# Altium schematic sheet PowerBoard Secondary.SchDoc
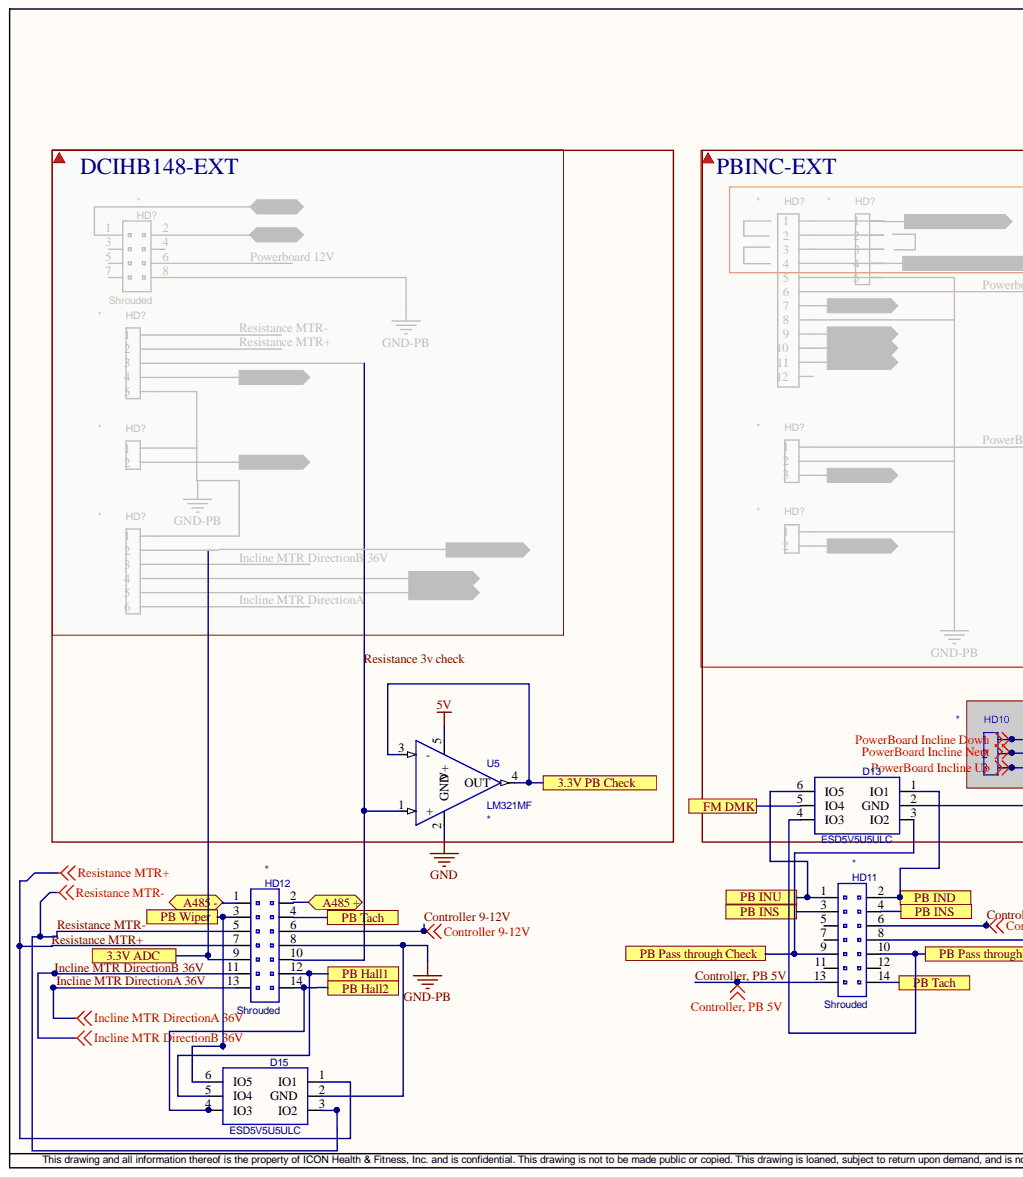
[diagram p0: PowerBoard Secondary.SchDoc, left]
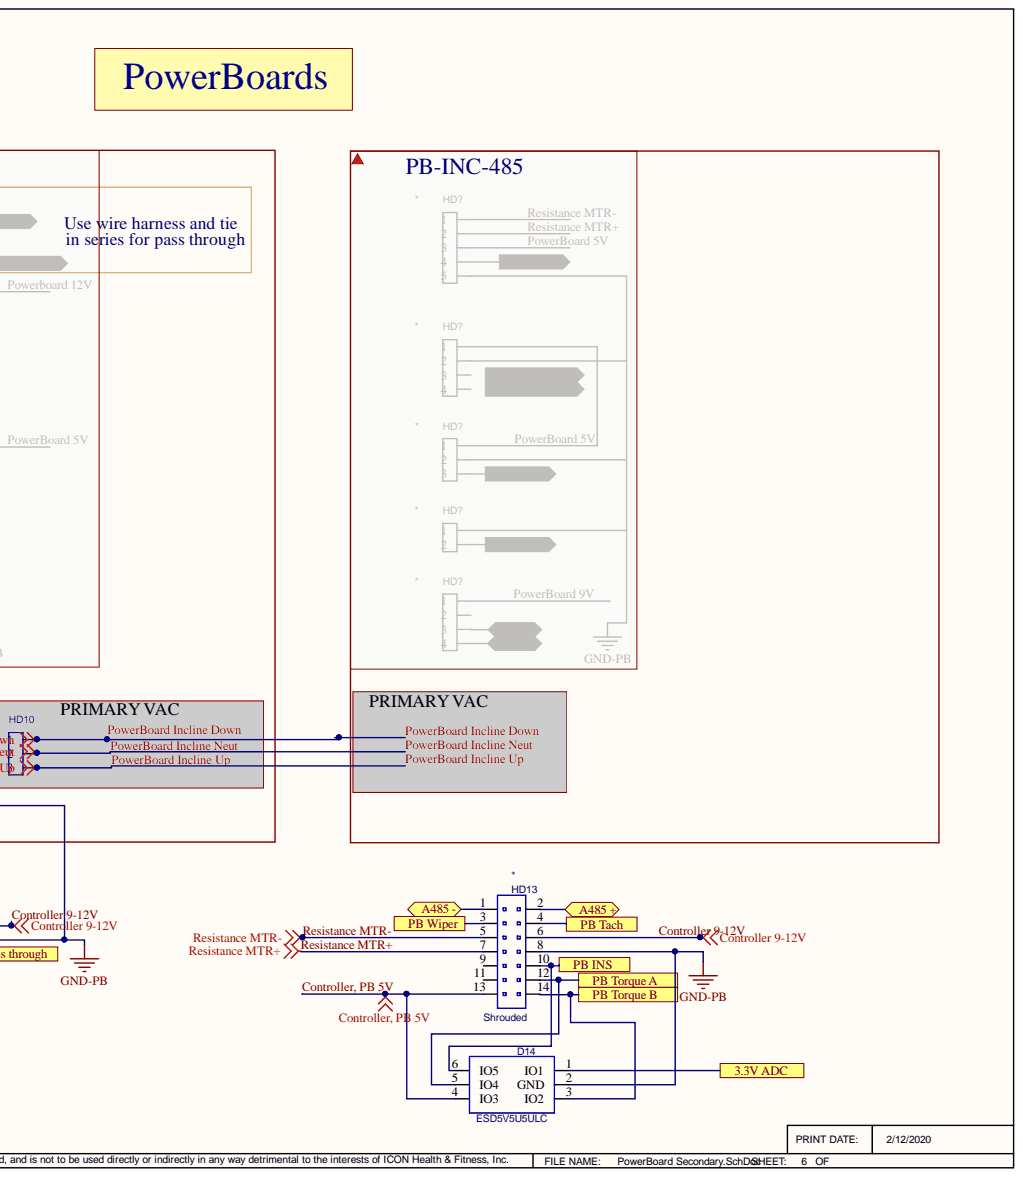
[diagram p1: PowerBoard Secondary.SchDoc, right]

## Parts
D13  ESD5V5U5ULC  [SOT457-6]
D14  ESD5V5U5ULC  [SOT457-6]
D15  ESD5V5U5ULC  [SOT457-6]
HD10  HDR3PIN  [HDR3PIN_JST_XA_2.5MM]  (value not drawn)
HD11  HeaderType: Shrouded  [HDR_2.5MM_14PIN_JST_XAD]  (hidden comment: HDR_14PIN_DUALROW_JST)
HD12  HeaderType: Shrouded  [HDR_2.5MM_14PIN_JST_XAD]  (hidden comment: HDR_14PIN_DUALROW_JST)
HD13  HeaderType: Shrouded  [HDR_2.5MM_14PIN_JST_XAD]  (hidden comment: HDR_14PIN_DUALROW_JST)
U5  value LM321MF  [SOT23-5_REFLOW]  (hidden comment: LM321_SOT23-5)

## Ports
3.3V ADC, 3.3V PB Check, A485 +, A485 -, FM DMK, PB Hall1, PB Hall2, PB IND, PB INS, PB INU, PB Pass through, PB Pass through Check, PB Tach, PB Torque A, PB Torque B, PB Wiper

## Nets
5V: U5.5(V+)
GND: U5.2(GND)
GND-PB: D13.2(GND) D14.2(GND) D15.2(GND) HD11.8 HD12.8 HD13.8
3.3V ADC: D14.1(IO1) HD12.9 ; port 3.3V ADC
3.3V PB Check: U5.3(-) U5.4(OUT) ; port 3.3V PB Check
Controller 9-12V: HD11.6 HD12.6 HD13.6
Controller, PB 5V: D14.4(IO3) HD11.13 HD13.13
FM DMK: D13.5(IO4) ; port FM DMK
Incline MTR DirectionA 36V: HD12.13
Incline MTR DirectionB 36V: HD12.11
PB Hall1: D15.5(IO4) HD12.12 ; port PB Hall1
PB Hall2: D15.4(IO3) HD12.14 ; port PB Hall2
PB IND: D13.1(IO1) HD11.2 ; port PB IND
PB INS: D14.6(IO5) HD11.3 HD11.4 HD13.10 ; port PB INS
PB INU: D13.6(IO5) HD11.1 ; port PB INU
PB Pass through: D13.4(IO3) HD11.10 ; port PB Pass through
PB Pass through Check: D13.3(IO2) HD11.9 ; port PB Pass through Check
PB Tach: HD11.14 HD12.4 HD13.4 ; port PB Tach
PB Torque A: D14.5(IO4) HD13.12 ; port PB Torque A
PB Torque B: D14.3(IO2) HD13.14 ; port PB Torque B
PB Wiper: D15.6(IO5) HD12.3 HD13.3 ; port PB Wiper
PowerBoard Incline Down: HD10.1
PowerBoard Incline Neut: HD10.2
PowerBoard Incline Up: HD10.3
Resistance 3v check: HD12.10 U5.1(+)
Resistance MTR+: D15.1(IO1) HD12.7 HD13.7
Resistance MTR-: D15.3(IO2) HD12.5 HD13.5
NetHD12_1: HD12.1 HD13.1
NetHD12_2: HD12.2 HD13.2

Not connected: HD11 5 7 11 12; HD13 9 11

## Library data of the parts
D13-D15 = 325030: Diode, ESD5V5U5ULC, TVS, 5V, TSOP6, Infineon: ESD5V5U5ULC; Database Table Name: AltiumComponents; IDFCOMP: 0; Component Kind: Standard; ComponentLink1Description: Datasheet; ComponentLink1URL: \\iconfitness.com\loganfiles\RND Departments\electronics\accel_lib\datasheets\Infineon-ESD5V5U5ULC-DS-v01_04-EN.pdf; ComponentLink4Description: PDM Parts Maintenance; ComponentLink4URL: https://pdm.iconfitness.com/PDM/EBOM/PartsMaintenance/PartsMaintenance.aspx?Editplidx=325030; ComponentType: Diode; Cost: 0.08; Datasheet: \\langley\electronics\accel_lib\datasheets\D\PESDXS4UD-NXP.pdf; DiodeType: TVS; ModifyDate: 07/31/2009 12:30:23; Package: TSOP6; plIDX: 325030; Value: ESD5V5U5ULC; Vendor: Infineon: ESD5V5U5ULC; Voltage: 5V; CheckedBy: T. Hayes; CreatedBy: Rui Zhang; LastUpdatedBy: Rui Zhang; Library Name: bome.DbLib
HD10 = 342209: Header, 3 Pins, 2.50mm, Vertical, Through hole, single row, Shrouded, JST: B03B-XASK-1-A; Database Table Name: AltiumComponents; Component Kind: Standard; ComponentLink1Description: Datasheet; ComponentLink1URL: \\iconfitness.com\loganfiles\RND Departments\electronics\accel_lib\Datasheets\eXA1.pdf; ComponentLink4Description: PDM Parts Maintenance; ComponentLink4URL: https://pdm.iconfitness.com/PDM/EBOM/PartsMaintenance/PartsMaintenance.aspx?Editplidx=342209; ComponentType: Header; Cost: 0.0592; HeaderType: Shrouded; LeadSpacing: 2.50mm; Package: Vertical, Through hole, single row; Pins: 3; plIDX: 342209; Vendor: JST: B03B-XASK-1-A; CreatedBy: Michael Angeli; LastUpdatedBy: Michael Angeli; Library Name: bome.DbLib
HD11-HD13 = 365385: Header, 14 Pins, 2.50mm, Vertical, Through hole, double row, Shrouded, JST: B14B-XADSS-N-A; Database Table Name: AltiumComponents; Component Kind: Standard; ComponentLink1Description: Datasheet; ComponentLink1URL: \\iconfitness.com\loganfiles\RND Departments\electronics\accel_lib\Datasheets\eXA1.pdf; ComponentLink4Description: PDM Parts Maintenance; ComponentLink4URL: https://pdm.iconfitness.com/PDM/EBOM/PartsMaintenance/PartsMaintenance.aspx?Editplidx=365385; ComponentType: Header; Cost: 0; LeadSpacing: 2.50mm; Package: Vertical, Through hole, double row; Pins: 14; plIDX: 365385; Value: B14B-XADSS-N-A; Vendor: JST: B14B-XADSS-N-A; CreatedBy: Ross Bunnell; LastUpdatedBy: Ross Bunnell; Library Name: bome.DbLib
U5 = 2715: IC, LM321MF, Op-Amp, SOT23-5, 5 Pins, TI: LM321MF/NOPB or Equivalent; Database Table Name: AltiumComponents; ChipType: Op-Amp; Component Kind: Standard; ComponentLink4Description: PDM Parts Maintenance; ComponentLink4URL: https://pdm.iconfitness.com/PDM/EBOM/PartsMaintenance/PartsMaintenance.aspx?Editplidx=2715; ComponentType: IC; Cost: 0.08; Datasheet: g:/accel_lib/datasheets/lm321-national.pdf; HelpURL: g:/accel_lib/datasheets/lm321-national.pdf; ModifyDate: 05/19/2006 16:04:01; Package: SOT23-5; Pins: 5; plIDX: 2715; Vendor: TI: LM321MF/NOPB or Equivalent; CheckedBy: Bret Lyon; LastUpdatedBy: Mike Tyger; Library Name: bome.DbLib
